# Altium schematic sheet Pulser_Top.SchDoc(Pulser_Top)
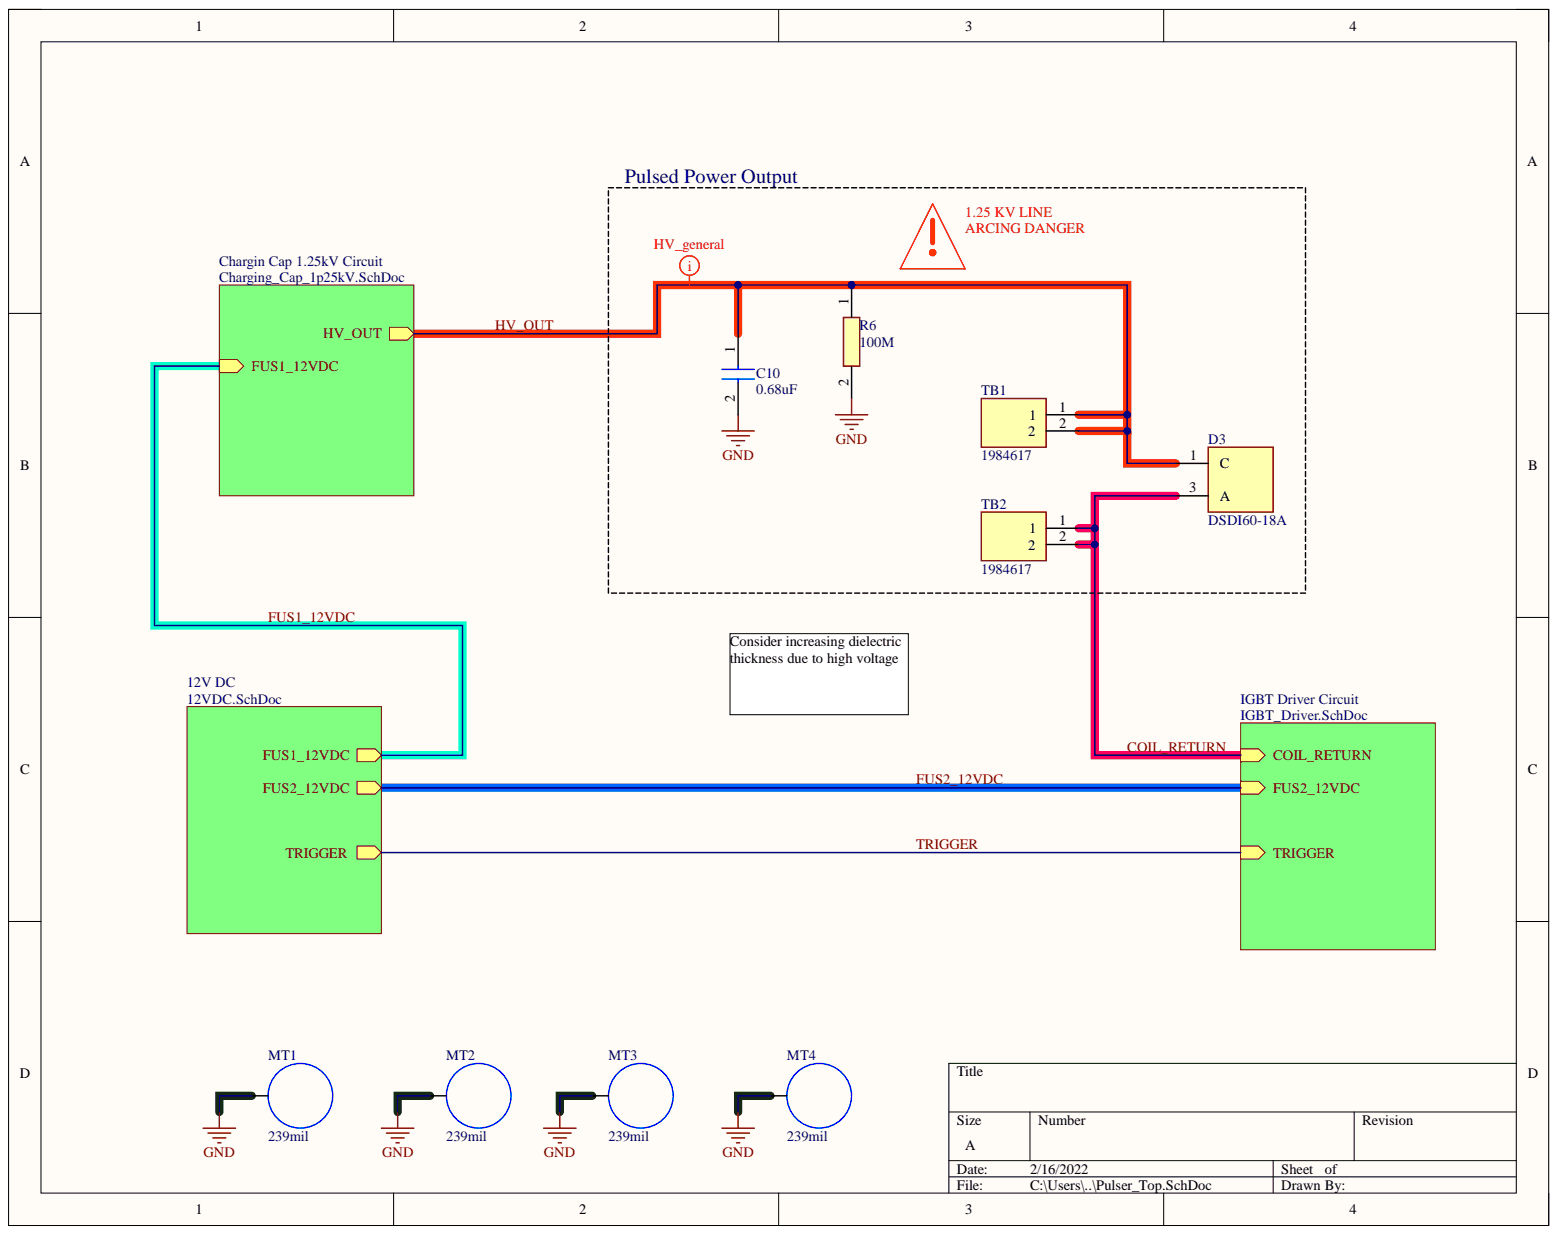
[diagram p0: Pulser_Top.SchDoc]

## Parts
C10  0.68uF  [MKP1839468134HQ]
D3  DSDI60-18A  [TO546P530X1586X2594-2P]
MT1  239mil  [MTHOLE_239mil]
MT2  239mil  [MTHOLE_239mil]
MT3  239mil  [MTHOLE_239mil]
MT4  239mil  [MTHOLE_239mil]
R6  100M  [HB3100MFZRE]
TB1  1984617  [1984617]
TB2  1984617  [1984617]

## Nets
GND: C10.2 MT1.1 MT2.1 MT3.1 MT4.1 R6.2
COIL_RETURN: D3.3 TB2.1 TB2.2
HV_OUT: C10.1 D3.1 R6.1 TB1.1 TB1.2
FUS1_12VDC:
FUS2_12VDC:
TRIGGER:

## Library data of the parts
C10 = MKP1839468134HQ: Capacitor MKP1839468134HQ; Manufacturer_Name: Vishay; Component Kind: Standard; Datasheet Link: https://www.vishay.com/docs/28162/mkp1839hq.pdf; Height: 28mm; Manufacturer_Part_Number: MKP1839468134HQ; Mouser Part Number: 75-MKP1839468134HQ; Mouser Price/Stock: https://www.mouser.com/Search/Refine.aspx?Keyword=75-MKP1839468134HQ; Library Name: pulser_driver_symbols.SchLib
D3 = DSDI60-18A: Diode; Manufacturer_Name: LITTELFUSE; Component Kind: Standard; Datasheet Link: http://ixapps.ixys.com/DataSheet/96517.pdf; Height: 5.3mm; Manufacturer_Part_Number: DSDI60-18A; Library Name: pulser_driver_symbols.SchLib
MT1-MT4 = MTHOLE_239MIL: Manufacturer: (NULL); Component Kind: Standard; Manufacturer Part Number: (NULL); Part Number: MTHOLE_239; Library Name: pulser_driver_symbols.SchLib
R6 = HB3100MFZRE: Resistor; Manufacturer_Name: TE Connectivity; Component Kind: Standard; Datasheet Link: http://www.te.com/commerce/DocumentDelivery/DDEController?Action=srchrtrv&DocNm=1773310&DocType=DS&DocLang=English; Manufacturer_Part_Number: HB3100MFZRE; Library Name: pulser_driver_symbols.SchLib
TB1 TB2 = 1984617: Connector; Manufacturer_Name: Phoenix Contact; Arrow Part Number: 1984617; Arrow Price/Stock: https://www.arrow.com/en/products/1984617/phoenix-contact?region=nac; Component Kind: Standard; Datasheet Link: https://datasheet.datasheetarchive.com/originals/distributors/Datasheets-DGA17/1074867.pdf; Height: 9.3mm; Manufacturer_Part_Number: 1984617; Mouser Part Number: 651-1984617; Mouser Price/Stock: https://www.mouser.co.uk/ProductDetail/Phoenix-Contact/1984617?qs=ATjOrvm3mOhUrGlJQoUcpQ%3D%3D; Library Name: pulser_driver_symbols.SchLib
